# Altium schematic sheet Smart Blinds Controller.SchDoc
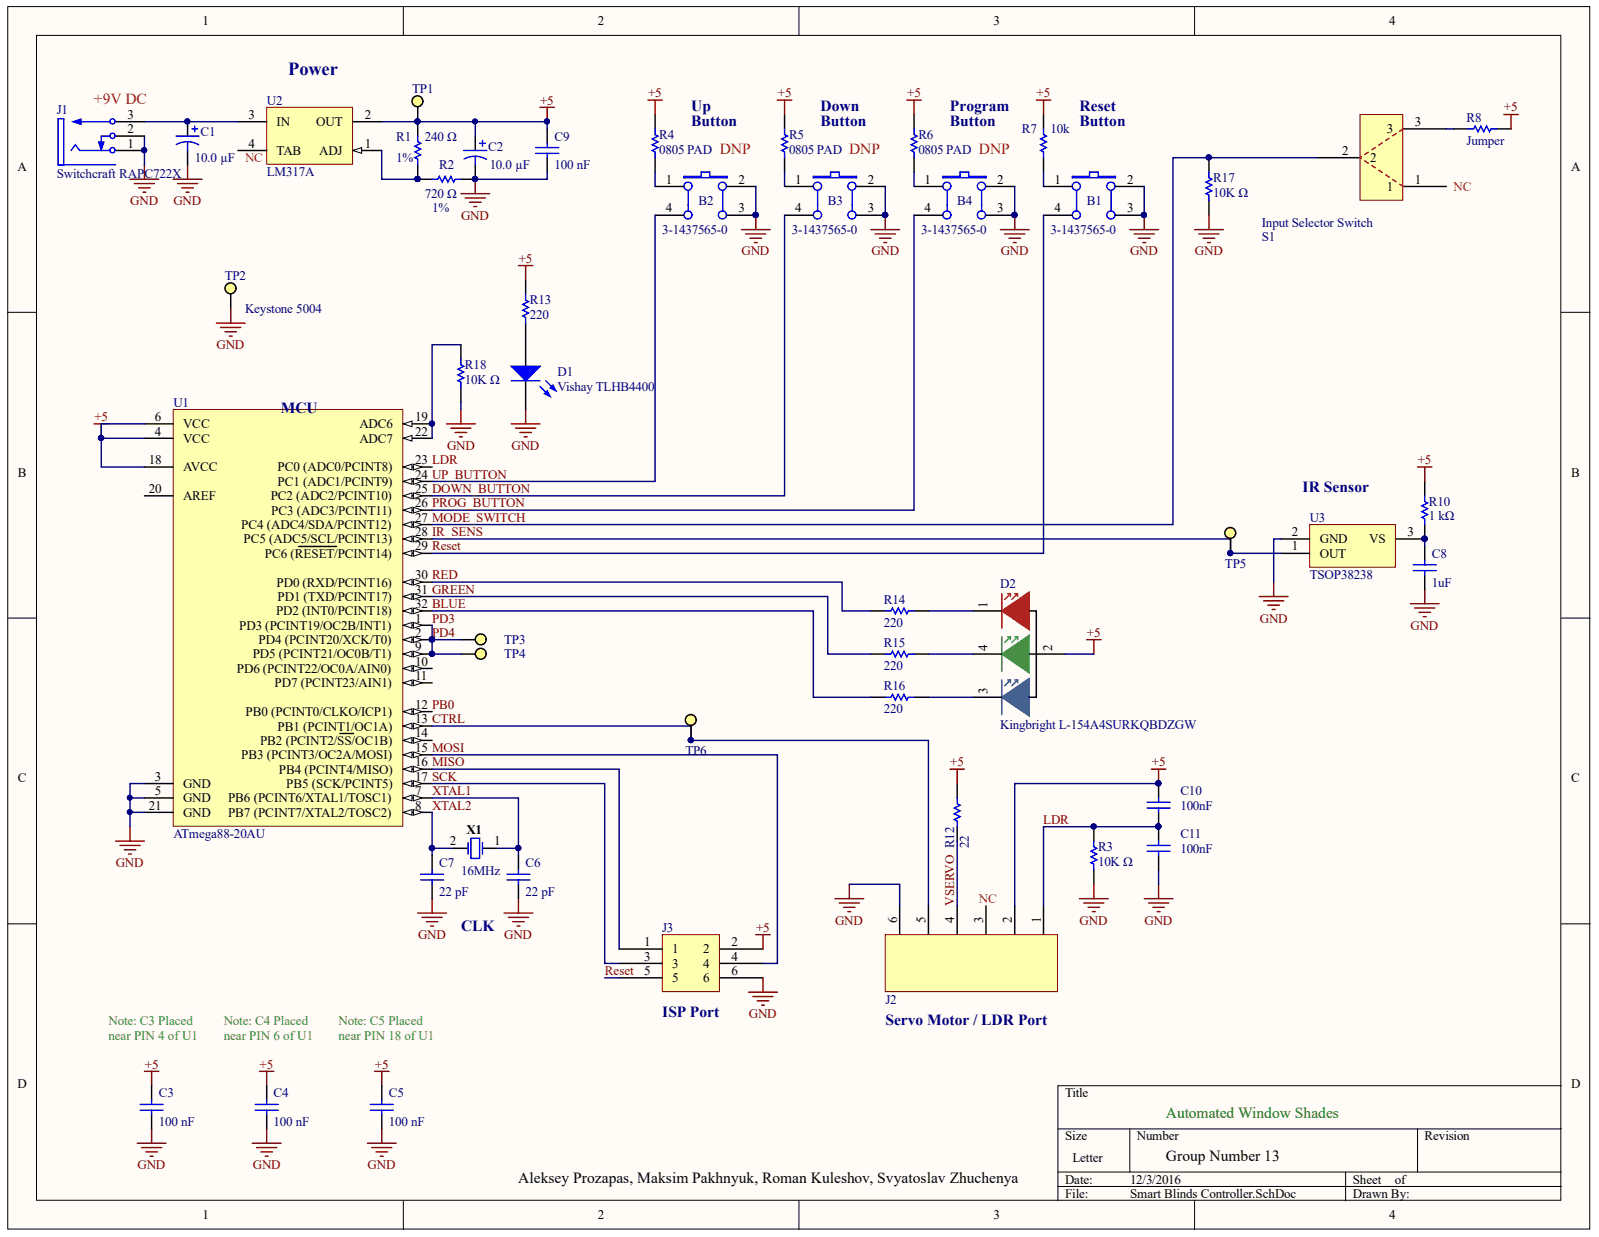
[diagram p0: Smart Blinds Controller.SchDoc]

## Parts
B1  3-1437565-0  [PB10]
B2  3-1437565-0  [PB10]
B3  3-1437565-0  [PB10]
B4  3-1437565-0  [PB10]
C1  Panasonic EEE-1CA100SR  [PCB-5c7lwdch6d5n3jfyiyq6-1]  (value not drawn)
C2  Panasonic EEE-1CA100SR  [PCB-5c7lwdch6d5n3jfyiyq6-1]  (value not drawn)
C3  Capacitance: 100 nF  [CAPC2013X135X45NL10T25]  (hidden comment: Cap)
C4  Capacitance: 100 nF  [CAPC2013X135X45NL10T25]  (hidden comment: Cap)
C5  Capacitance: 100 nF  [CAPC2013X135X45NL10T25]  (hidden comment: Cap)
C6  Capacitance: 22 pF  [CAPC2013X135X45NL10T25]  (hidden comment: Cap)
C7  Capacitance: 22 pF  [CAPC2013X135X45NL10T25]  (hidden comment: Cap)
C8  Capacitance: 1uF  [CAPC2013X135X45NL10T25]  (hidden comment: Cap)
C9  Capacitance: 100 nF  [CAPC2013X135X45NL10T25]  (hidden comment: Cap)
C10  Capacitance: 100nF  [CAPC2013X135X45NL10T25]  (hidden comment: Cap)
C11  Capacitance: 100nF  [CAPC2013X135X45NL10T25]  (hidden comment: Cap)
D1  Vishay TLHB4400  [PCB-4a8pfbh37xoew9kpfqzw-1]
D2  Kingbright L-154A4SURKQBDZGW  Manufacturer Part Number: L-154A4SURKQBDZGW  [PCB-26vx87la40gxc8g3sql7-1]
J1  Switchcraft RAPC722X  Manufacturer Part Number: RAPC722X  [PCB-1fbtkpzwj6p6nhvlt2g3-1]
J2  Molex 90121-0126  [PCB-642505-1]  (value not drawn)
J3  FCI 67997-106HLF  [PCB-94q9r8plw7fzhg0k9c12-1]  (value not drawn)
R1  Tolerance: 1%  [RESC2013X50X30ML20T20]  (hidden comment: 0803 Resistor)
R2  Resistance: 720 Ω  Tolerance: 1%  [RESC2013X50X30ML20T20]  (hidden comment: 0803 Resistor)
R3  0803 Resistor  [RESC2013X50X30ML20T20]  (value not drawn)
R4  Case/Package: 0805  [RESC2013X50X30ML20T20]  (hidden comment: 0803 Resistor)
R5  Case/Package: 0805  [RESC2013X50X30ML20T20]  (hidden comment: 0803 Resistor)
R6  Case/Package: 0805  [RESC2013X50X30ML20T20]  (hidden comment: 0803 Resistor)
R7  Resistance: 10k  [RESC2013X50X30ML20T20]  (hidden comment: 0803 Resistor)
R8  Resistance: Jumper  [RESC2013X50X30ML20T20]  (hidden comment: 0803 Resistor)
R10  Resistance: 1 kΩ  [RESC2013X50X30ML20T20]  (hidden comment: 0803 Resistor)
R12  0803 Resistor  [RESC2013X50X30ML20T20]  (value not drawn)
R13  0803 Resistor  [RESC2013X50X30ML20T20]  (value not drawn)
R14  0803 Resistor  [RESC2013X50X30ML20T20]  (value not drawn)
R15  0803 Resistor  [RESC2013X50X30ML20T20]  (value not drawn)
R16  0803 Resistor  [RESC2013X50X30ML20T20]  (value not drawn)
R17  0803 Resistor  [RESC2013X50X30ML20T20]  (value not drawn)
R18  0803 Resistor  [RESC2013X50X30ML20T20]  (value not drawn)
S1  TE Connectivity / Alcoswitch 1825255-1  [PCB-5fuzep5js76s1hsdheqo-1]  (value not drawn)
TP1  Keystone 5004  [PCB-zvn2rqiw0k10ubrhwk2m-1]
TP2  Keystone 5004  Manufacturer: Keystone  [PCB-zvn2rqiw0k10ubrhwk2m-1]
TP3  Keystone 5004  [PCB-zvn2rqiw0k10ubrhwk2m-1]
TP4  Keystone 5004  [PCB-zvn2rqiw0k10ubrhwk2m-1]
TP5  Keystone 5004  [PCB-zvn2rqiw0k10ubrhwk2m-1]
TP6  Keystone 5004  [PCB-zvn2rqiw0k10ubrhwk2m-1]
U1  ATmega88-20AU  [32A_M]
U2  LM317A  [MP04A_L]
U3  TSOP38238  [VISH-TSOP38238]
X1  Frequency: 16MHz  [FOX-FOXSLF-2_V]  (hidden comment: FOX Electronics FOXSLF/120-20)

## Nets
+5: C2.1 C3.2 C4.2 C5.2 C9.2 C10.2 D2.2 J2.2 J3.2 R1.1 R4.2 R5.2 R6.2 R7.1 R8.1 R10.2 R12.2 R13.1 TP1.TP U1.4(VCC) U1.6(VCC) U1.18(AVCC) U2.2(OUT)
GND: B1.2 B1.3 B2.2 B2.3 B3.2 B3.3 B4.2 B4.3 C1.2 C2.2 C3.1 C4.1 C5.1 C6.1 C7.1 C8.1 C9.1 C11.1 D1.2 J1.1 J1.2 J2.6 J3.6 R2.2 R3.2 R17.2 R18.2 TP2.TP U1.3(GND) U1.5(GND) U1.21(GND) U3.2
BLUE: R16.1 U1.32(PD2_(INT0/PCINT18))
CTRL: J2.5 TP6.TP U1.13(PB1_(PCINT1/OC1A))
DOWN_BUTTON: B3.4 U1.25
GREEN: R15.1 U1.31(PD1_(TXD/PCINT17))
IR_SENS: TP5.TP U1.28(PC5_(ADC5/SCL/PCINT13)) U3.1
LDR: C10.1 C11.2 J2.1 R3.1 U1.23(PC0_(ADC0/PCINT8))
MISO: J3.1 U1.16(PB4_(PCINT4/MISO))
MODE_SWITCH: R17.1 S1.2 U1.27(PC4_(ADC4/SDA/PCINT12))
MOSI: J3.4 U1.15(PB3_(PCINT3/OC2A/MOSI))
PB0: U1.12(PB0_(PCINT0/CLKO/ICP1))
PD3: TP3.TP TP4.TP U1.1(PD3_(PCINT19/OC2B/INT1)) U1.2(PD4_(PCINT20/XCK/T0)) U1.9(PD5_(PCINT21/OC0B/T1))
PROG_BUTTON: B4.4 U1.26(PC3_(ADC3/PCINT11))
RED: R14.1 U1.30(PD0_(RXD/PCINT16))
RESET: B1.4 J3.5 U1.29(PC6_(RESET/PCINT14))
SCK: J3.3 U1.17(PB5_(SCK/PCINT5))
UP_BUTTON: B2.4 U1.24(PC1_(ADC1/PCINT9))
VSERVO: J2.4 R12.1
XTAL1: C6.2 U1.7 X1.1
XTAL2: C7.2 U1.8 X1.2
NetB1_1: B1.1 R7.2
NetB2_1: B2.1 R4.1
NetB3_1: B3.1 R5.1
NetB4_1: B4.1 R6.1
NetC1_1: C1.1 J1.3 U2.3(IN)
NetC8_2: C8.2 R10.1 U3.3
NetD1_1: D1.1 R13.2
NetD2_1: D2.1 R14.2
NetD2_3: D2.3 R16.2
NetD2_4: D2.4 R15.2
NetR1_2: R1.2 R2.1 U2.1(ADJ)
NetR8_2: R8.2 S1.3
NetR18_1: R18.1 U1.19(ADC6) U1.22(ADC7)

Not connected: J2 3; S1 1; U1 10(PD6_(PCINT22/OC0A/AIN0)) 11(PD7_(PCINT23/AIN1)) 14(PB2_(PCINT2/SS/OC1B)) 20(AREF); U2 4(TAB)

## Library data of the parts
B1-B4 = CMP-78abb364fb89a76c-1: Switch Tactile OFF (ON) SPST Round Button Gull Wing 0.05A 24VDC 1VA 9.18N SMD T/R; Contact Current Rating: 50.0 mA; ELV Compliant: Compliant; Lead-Free Status: Lead Free; Component Kind: Standard; Contact Material: Copper Alloy; Contact Plating: Silver; Contacts Type: SPST; Library Name: CommunityVault; Lifecycle Status: Active; Manufacturer Part Number: 3-1437565-0; Mounting Style: Surface Mount; OctopartID: 78abb364fb89a76c; Octopart Url: http://octopart.com/3-1437565-0-te+connectivity-46507588; Packaging: Tape & Reel (TR); REACH SVHC Compliance: No SVHC; RoHS: Compliant; Seller Landing  Url: https://octopart-clicks.com/click/track?country=US&ak=8157f166&sig=08be3c5&sid=1106&ppid=46507588&vpid=118950543&ct=offers
C1 C2 = CMP-1d6cd72bfd77c2ab-5: Capacitor Alum Elec, 10uf, 16v, 20%, Scapacitor Alum Elec, 10uf, 16v, 20%, Smd, Full Reel; Capacitance: 10.0 µF; Dielectric Material: Aluminum Electrolytic; Lead-Free Status: Lead Free; Lead Length: 1.80 mm; Voltage Rating (DC): 16.0 V; Capacitance Tolerance: ±20%; Component Kind: Standard; Library Name: CommunityVault; Lifecycle Status: Not Listed by Manufacturer; Manufacturer Part Number: EEE-1CA100SR; Mounting Style: Surface Mount; Number of Pins: 2; OctopartID: 1d6cd72bfd77c2ab; Octopart URL: http://octopart.com/eee-1ca100sr-panasonic-55428477; Packaging: Cut Tape (CT); REACH SVHC Compliance: No SVHC; RoHS: Compliant; Size-Diameter: 4.00 mm; Size-Height: 5.40 mm
C3-C11 = CMP-3c98f6df3913e35f-5: 0805 100 nF 50V ±10 % Tolerance X7R Surface Mount Multilayer Ceramic Capacitor; Component Kind: Standard; Library Name: CommunityVault; Mounting Style: Surface Mount; ROHS: Compliant; Temperature Coefficient: X7R; Voltage - Rated: 50 V
D1 = CMP-13611427-7: 3 mm (T-1) Blue 60 º Min 6.3 mcd Typical 15 mcd Tinted Diffused Through-hole LED; Forward Voltage: 3.90 V; Lead-Free Status: Lead Free; Luminous Intensity: 15.0 mcd; Power Dissipation: 100 mW; Viewing Angle: 30 °; Wavelength: 466 nm; Color: Blue; Component Kind: Standard; Library Name: CommunityVault; Manufacturer Part Number: TLHB4400; Mounting Style: Through Hole, Radial; Number of Pins: 2; OctopartID: 6875a32548edb7b2; Octopart URL: http://octopart.com/tlhb4400-vishay-39454206; Packaging: Bulk; RoHS: Compliant; Size-Diameter: 3.20 mm; Voltage Rating (DC): 3.90 V
D2 = CMP-6e56a6000ed7b191-6: Led, Multi-Colour, Rgb, 5mm,; Viewing Angle: 60 °; Component Kind: Standard; Library Name: CommunityVault; OctopartID: 6e56a6000ed7b191; Octopart URL: http://octopart.com/l-154a4surkqbdzgw-kingbright-22824423; REACH SVHC Compliance: No SVHC; RoHS: Compliant
J1 = CMP-da391331d91005fe-5: Conn; AV; Power; Jack; Mini; 2.0mmPinDia; RtAngle; Thru-hole; forS760, S765Plug; Current Rating: 5.0 A; Gender: Female; Lead-Free Status: Lead Free; Mounting Angle: 90.0 °; Number of Contacts: 24; Voltage Rating (AC): 250.0 V; Color: Black; Component Kind: Standard; Contact Plating: Nickel; Library Name: CommunityVault; Mounting Style: Through Hole, PCB, Solder; OctopartID: da391331d91005fe; Octopart URL: http://octopart.com/rapc722x-switchcraft-140879; Packaging: Bulk; REACH SVHC Compliance: No SVHC; RoHS: Compliant
J2 = CMP-642505-3: Headers & Wire Housings 2.54MM CGRIDIII HDR 6P R/A SR TIN; Current Rating: 3.0 A; Gender: Male; Glow Wire Compliant: Non-Compliant; Lead-Free Status: Lead Free; Number of Circuits: 6; Pin Pitch: 0.00254 m; Component Kind: Standard; Contact Material: Brass; Contact Plating: Tin; Halogen Free Status: Not Low Halogen; Housing Color: Black; Housing Material: Plastic; Library Name: CommunityVault; Lifecycle Status: Active; Number of Contacts: 6; Number of Positions: 6; Number of Rows: 1; OctopartID: 597a907a7c4386bd; Octopart Url: https://octopart.com/90121-0126-molex+%2F+waldom-52562272; Orientation: Right Angle; Packaging: Bag; REACH SVHC Compliance: No SVHC; RoHS: Compliant; Size-Height: 0.0036 m; Size-Length: 0.01524 m; Size-Width: 0.0025 m
J3 = CMP-c8f30399bb8b1734-1: Conn; Rect; Header; Unshrouded; 6 Pos; 2.54mm Pitch; 2-Row; Thru-Hole; BergStik; Gender: Male; Lead-Free Status: Lead Free; Number of Contacts: 6; Component Kind: Standard; Contact Material: Phosphor Bronze; Contact Plating: Gold; Library Name: CommunityVault; Manufacturer Part Number: 67997-106HLF; Mounting Style: Through Hole; Number of Positions: 6; Number of Rows: 2; OctopartID: c8f30399bb8b1734; Octopart URL: http://octopart.com/67997-106hlf-fci-39867934; Pin Pitch: 2.54 mm; RoHS: Compliant
R1 = CMP-1860218-2: RES SMD 240 OHM ***W 0805; Lead-Free Status: Lead Free; Resistance: 240 Ω; Voltage Rating (DC): ***; Case/Package: 0805; Component Kind: Standard; Library Name: CommunityVault; Number of Pins: 2; Power Rating: *** W
R2 R7 R8 R10 = CMP-1860218-2: RES SMD 240 OHM ***W 0805; Lead-Free Status: Lead Free; Voltage Rating (DC): ***; Case/Package: 0805; Component Kind: Standard; Library Name: CommunityVault; Number of Pins: 2; Power Rating: *** W
R3 R17 R18 = CMP-1860218-2: RES SMD 240 OHM ***W 0805; Lead-Free Status: Lead Free; Resistance: 10K Ω; Voltage Rating (DC): ***; Case/Package: 0805; Component Kind: Standard; Library Name: CommunityVault; Number of Pins: 2; Power Rating: *** W
R4-R6 = CMP-1860218-2: RES SMD 240 OHM ***W 0805; Lead-Free Status: Lead Free; Resistance: 0805 PAD; Voltage Rating (DC): ***; Component Kind: Standard; Library Name: CommunityVault; Number of Pins: 2; Power Rating: *** W
R12 = CMP-1860218-2: RES SMD 240 OHM ***W 0805; Lead-Free Status: Lead Free; Resistance: 22; Voltage Rating (DC): ***; Case/Package: 0805; Component Kind: Standard; Library Name: CommunityVault; Number of Pins: 2; Power Rating: *** W
R13-R16 = CMP-1860218-2: RES SMD 240 OHM ***W 0805; Lead-Free Status: Lead Free; Resistance: 220; Voltage Rating (DC): ***; Case/Package: 0805; Component Kind: Standard; Library Name: CommunityVault; Number of Pins: 2; Power Rating: *** W
S1 = CMP-89c042a2c28b5607-2: Switch Slide ON None ON SPDT Top Slide 0.3A 125VAC PC Pins Thru-Hole Tray; ELV Compliant: Compliant; Lead-Free Status: Lead Free; Number of Positions: 2; Component Kind: Standard; Contact Material: Copper Alloy; Contact Plating: Silver; Contacts Type: SPDT; Flammability Rating: UL 94 HB; Library Name: CommunityVault; Lifecycle Status: Active; Manufacturer Part Number: 1825255-1; Mounting Style: Through Hole; OctopartID: 89c042a2c28b5607; Octopart URL: http://octopart.com/1825255-1-te+connectivity+%2F+alcoswitch-40398381; Orientation: Vertical; Packaging: Tray; RoHS: Compliant
TP1-TP6 = CMP-be0a7700f4c361cd-3: Test Point; Yellow; Thru-Hole; Snap-Fit; SilverPlate; 0.040 in. Dia.; 0.300 In.; Manufacturer Part Number: 5004; Lead-Free Status: Lead Free; Color: Yellow; Component Kind: Standard; Contact Material: Phosphor Bronze; Contact Plating: Tin; Library Name: CommunityVault; Mounting Style: Through Hole, PCB; OctopartID: be0a7700f4c361cd; Octopart URL: http://octopart.com/5004-keystone-28591; Packaging: Bulk; RoHS: Compliant; Size-Diameter: 2.54mm; Size-Height: 7.62mm; Size-Inner Diameter: 1.27mm; Size-Length: 7.62mm
  TP1 TP3-TP6: Manufacturer: Keystone
U1 = CMP-14040113-2: 8-bit AVR Microcontroller, 2.7-5.5V, 20MHz, 8KB Flash, 512 Bytes EEPROM, 1KB SRAM, 32-pin TQFP, Industrial Grade (-40°C to 85°C); Access Time: 2e-05 s; Clock Speed: 20000000.0 Hz; FLASH Memory Size: 8192 B; Lead-Free Status: Lead Free; Number of Bits: 8; Number of I/O Pins: 23; RAM Memory Size: 1024 B; Supply Voltage (DC): 5.0 V; Case/Package: TQFP; Component Kind: Standard; Core Architecture: AVR; Library Name: CommunityVault; Mounting Style: Surface Mount; Number of Pins: 32; OctopartID: 64f0af98262ebb37; Octopart Url: https://octopart.com/atmega88-20au-atmel-40003512; REACH SVHC Compliance: No SVHC; RoHS: Compliant
U2 = CMP-1171139-3: 3-Terminal Adjustable Regulator, 4-pin SOT-223, Pb-Free; Manufacturer Part Number: LM317AEMP/NOPB; Current Rating: 1.0 A; Dropout Voltage: 2.25 V; Lead-Free Status: Lead Free; Number of Outputs: 1; Output Capacitor Type: Tantalum; Quiescent Current: 0.0035 A; Case/Package: SOT-223; Component Kind: Standard; Library Name: CommunityVault; Lifecycle Status: Active; Mounting Style: Surface Mount; Number of Pins: 4; Number of Regulated Outputs: 1; OctopartID: 1725df559201c8e2; Octopart Url: https://octopart.com/lm317aemp%2Fnopb-texas+instruments-24813634; Output Current: 1.0 A; REACH SVHC Compliance: No SVHC; RoHS: Compliant
U3 = CMP-2128831-6: IR Receiver Modules for Remote Control System, 2.5 to 5.5 V, -25 to 85 degC, 3-Pin THD, RoHS, Bulk; Lead-Free Status: Lead Free; Supply Current: 350 µA; Supply Voltage (DC): 2.50 V (min); Component Kind: Standard; DatasheetVersion: Rev. 1.5, 07/2016; Library Name: CommunityVault; Manufacturer Part Number: TSOP38238; OctopartID: 0b79c2271f9ed4be; Octopart Url: http://octopart.com/tsop38238-vishay-5517697; PackageDescription: 3-Pin Through Hole Device, Body 5.00 x 4.80 mm, Pitch 2.54 mm; PackageReference: VISH-TSOP38238; RoHS: Compliant; Seller Landing  Url: https://octopart-clicks.com/click/track?country=US&ak=8157f166&sig=0bc2f5f&sid=459&ppid=5517697&vpid=46969866&ct=offers
X1 = CMP-9f2a56215a2340ed-1: Resistance Weld Thru-Hole Crystal, 12 MHz, +/-30 ppm, -20 to 70 degC, 2-Pin THD, RoHS, Bulk; Lead-Free Status: Lead Free; Load Capacitance: 20.0 pF; Case/Package: HC-49; Component Kind: Standard; DatasheetVersion: Mar-15; Library Name: CommunityVault; Manufacturer Part Number: FOXSLF/120-20; Mounting Style: Through Hole; Number of Pins: 2; OctopartID: 9f2a56215a2340ed; Octopart Url: http://octopart.com/foxslf%2F120-20-fox+electronics-122991; PackageDescription: 2-Pin Through Hole Device, Body 11.35 x 4.65 mm, Pitch 4.88 mm; PackageReference: FOXSLF-2; Packaging: Bulk; REACH SVHC Compliance: No SVHC; RoHS: Compliant; Seller Landing  Url: https://octopart-clicks.com/click/track?country=US&ak=8157f166&sig=0ea0d36&sid=1106&ppid=122991&vpid=54020415&ct=offers; Size-Height: 3.60 mm; Size-Length: 11.4 mm; Size-Width: 4.65 mm
